# Altium schematic sheet Display.SchDoc
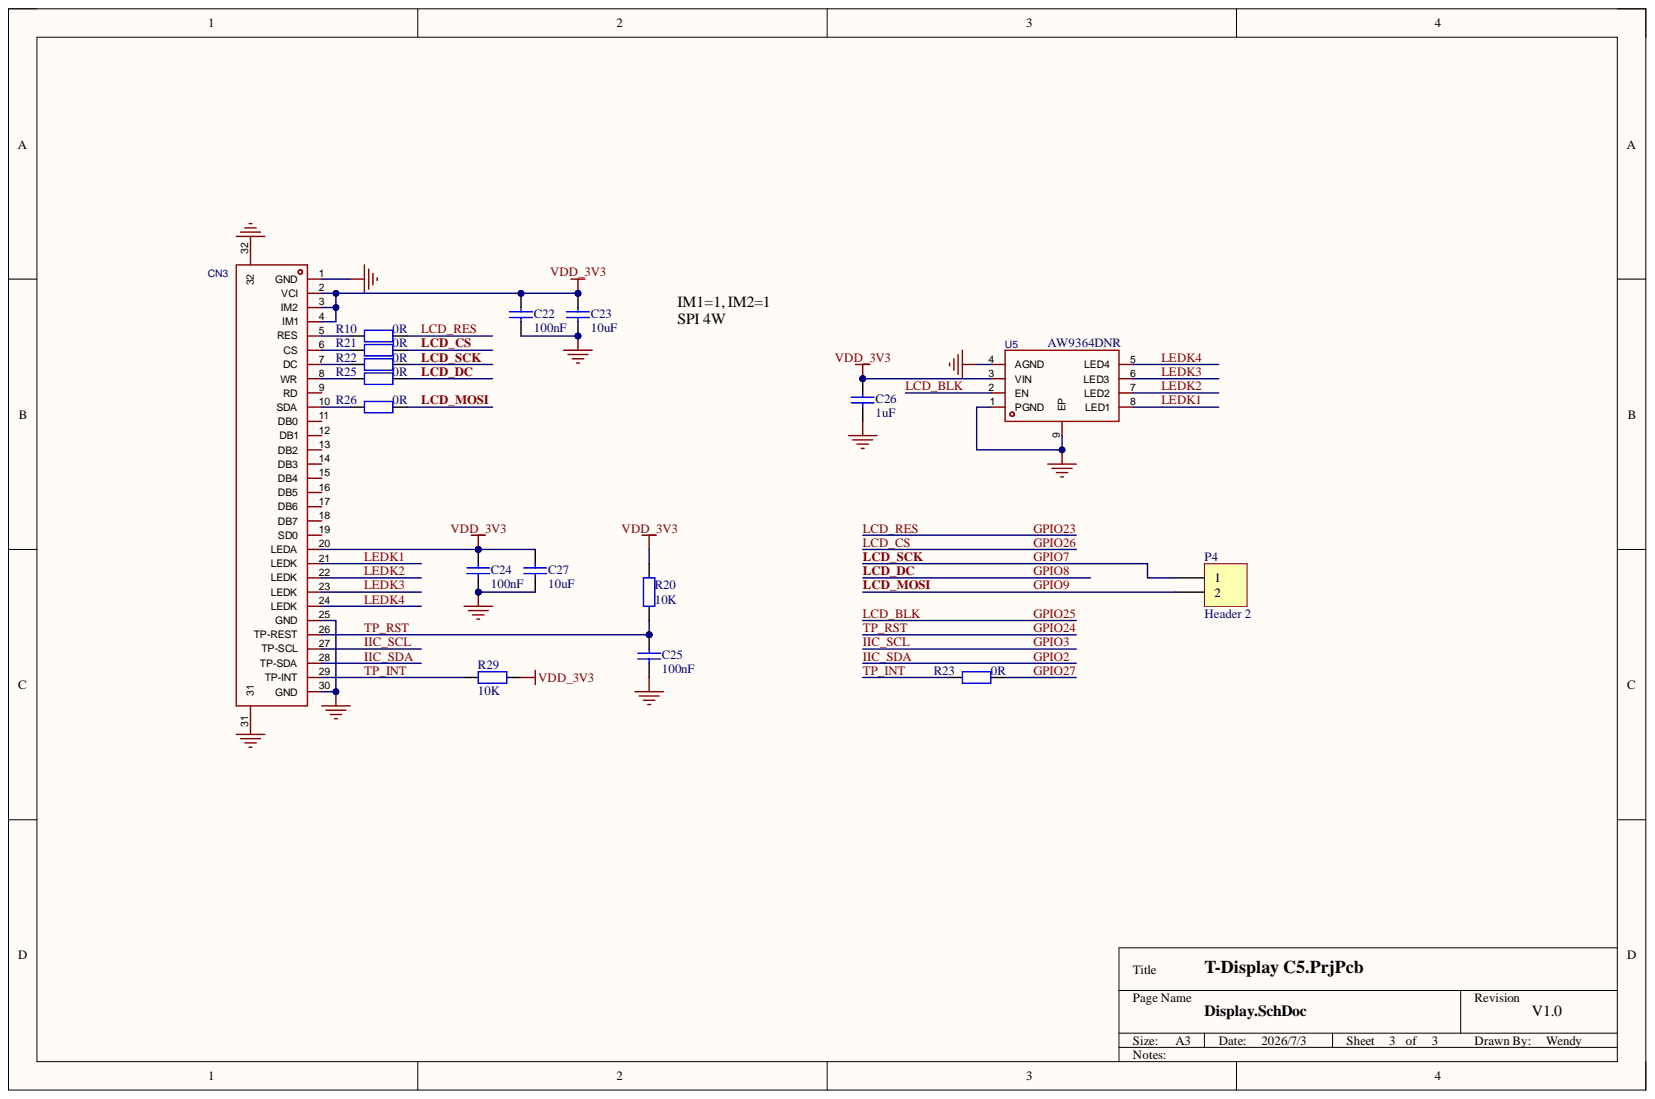
[diagram p0: Display.SchDoc]

## Parts
C22  100nF  [C0402]
C23  10uF  [C0402]
C24  100nF  [C0402]
C25  100nF  [C0402]
C26  1uF  [C0402]
C27  10uF  [C0402]
CN3  KH-FG0.5-H2.0-30PIN  [FPC-SMD_KH-FG0.5-H2.0-30PIN]  (value not drawn)
P4  Header 2  [HDR1X2]
R10  0R  [R0402]
R20  10K  [R0402]
R21  0R  [R0402]
R22  0R  [R0402]
R23  0R  [R0402]
R25  0R  [R0402]
R26  0R  [R0402]
R29  10K  [R0402]
U5  AW9364DNR  [DFN-8_L2.0-W2.0-P0.50-BL-EP-1]

## Nets
GND: C22.2 C23.2 C24.1 C25.1 C26.2 C27.1 CN3.1(GND) CN3.25(GND) CN3.30(GND) CN3.31 CN3.32 U5.1(PGND) U5.4(AGND) U5.9(EP)
VDD_3V3: C22.1 C23.1 C24.2 C26.1 C27.2 CN3.2(VCI) CN3.3(IM2) CN3.4(IM1) CN3.20(LEDA) R20.1 R29.1 U5.3(VIN)
GPIO2: CN3.28(TP-SDA)
GPIO3: CN3.27(TP-SCL)
GPIO7: P4.1 R22.1
GPIO8: R25.1
GPIO9: P4.2 R26.1
GPIO23: R10.1
GPIO24: C25.2 CN3.26(TP-REST) R20.2
GPIO25: U5.2(EN)
GPIO26: R21.1
GPIO27: R23.2
LEDK1: CN3.21(LEDK) U5.8(LED1)
LEDK2: CN3.22(LEDK) U5.7(LED2)
LEDK3: CN3.23(LEDK) U5.6(LED3)
LEDK4: CN3.24(LEDK) U5.5(LED4)
TP_INT: CN3.29(TP-INT) R23.1 R29.2
NetCN3_5: CN3.5(RES) R10.2
NetCN3_6: CN3.6(CS) R21.2
NetCN3_7: CN3.7(DC) R22.2
NetCN3_8: CN3.8(WR) R25.2
NetCN3_10: CN3.10(SDA) R26.2

Not connected: CN3 9(RD) 11(DB0) 12(DB1) 13(DB2) 14(DB3) 15(DB4) 16(DB5) 17(DB6) 18(DB7) 19(SD0)

## Library data of the parts
C22-C27 = Cap: Capacitor; Component Kind: Standard; LatestRevisionDate: 17-Jul-2002; LatestRevisionNote: Re-released for DXP Platform.; Library Name: Miscellaneous Devices.IntLib; PackageDescription: Radial Cap, Thru-Hole; 2 Leads; 0.3 in Pin Spacing; PackageReference: RAD-0.3; Published: 8-Jun-2000; Signal Integrity: Cap; Simulation: CAP; Publisher: Altium Limited
  C22 C24 C25: Value: 100nF
  C23 C27: Value: 10uF
  C26: Value: 1uF
P4 = Header 2: Header, 2-Pin; Component Kind: Standard; LatestRevisionDate: 17-Jul-2002; LatestRevisionNote: Re-released for DXP Platform.; Library Name: Miscellaneous Connectors.IntLib; Signal Integrity: Connector; Publisher: Altium Limited
R10 R20-R23 R25 R26 R29 = Res2: Resistor; Component Kind: Standard; LatestRevisionDate: 17-Jul-2002; LatestRevisionNote: Re-released for DXP Platform.; Library Name: Miscellaneous Devices.IntLib; PackageDescription: Axial Device, Thru-Hole; 2 Leads; 0.4 in Pin Spacing; PackageReference: AXIAL-0.4; Published: 8-Jun-2000; Signal Integrity: Res; Simulation: RESISTOR; Publisher: Altium Limited
  R10 R21-R23 R25 R26: Value: 0R
  R20 R29: Value: 10K
CN3 = KH-FG0.5-H2.0-30PIN: Component Kind: Standard; Symbol: KH-FG0.5-H2.0-30PIN; Library Name: WendyLib.SchLib
U5 = AW9364DNR: Component Kind: Standard; Symbol: AW9364DNR
